# Altium schematic sheet IO.SchDoc
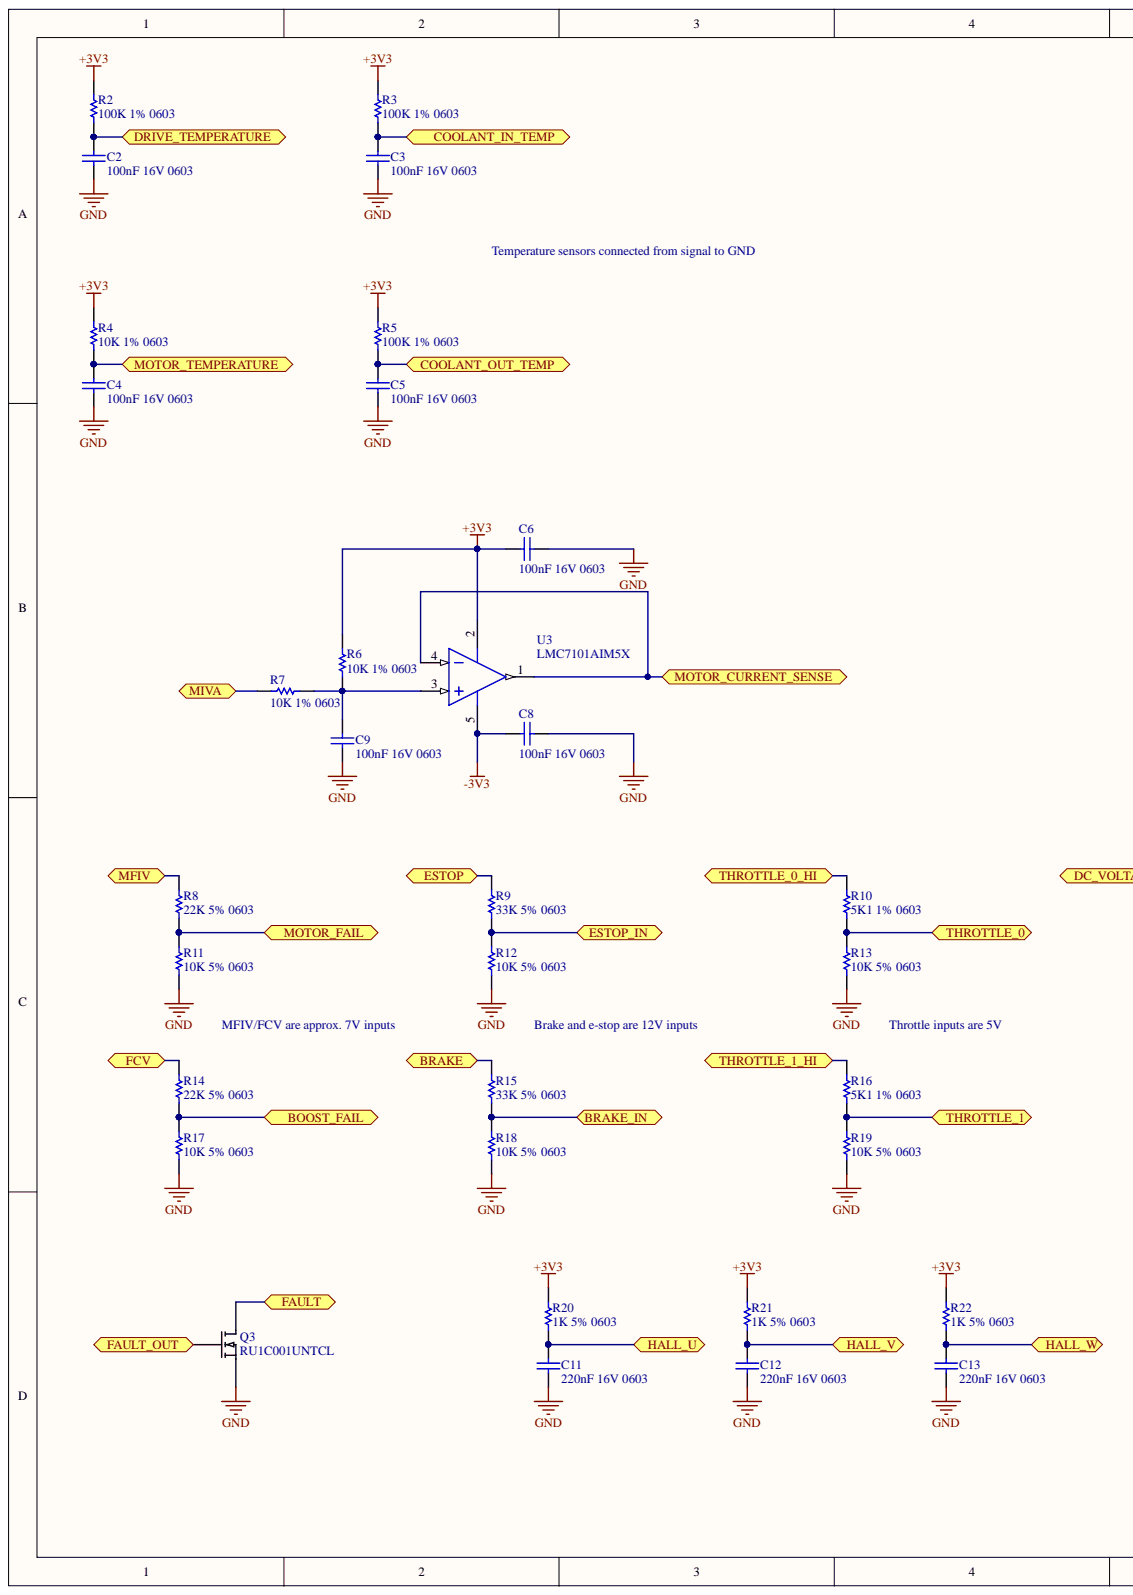
[diagram p0: IO.SchDoc, left]
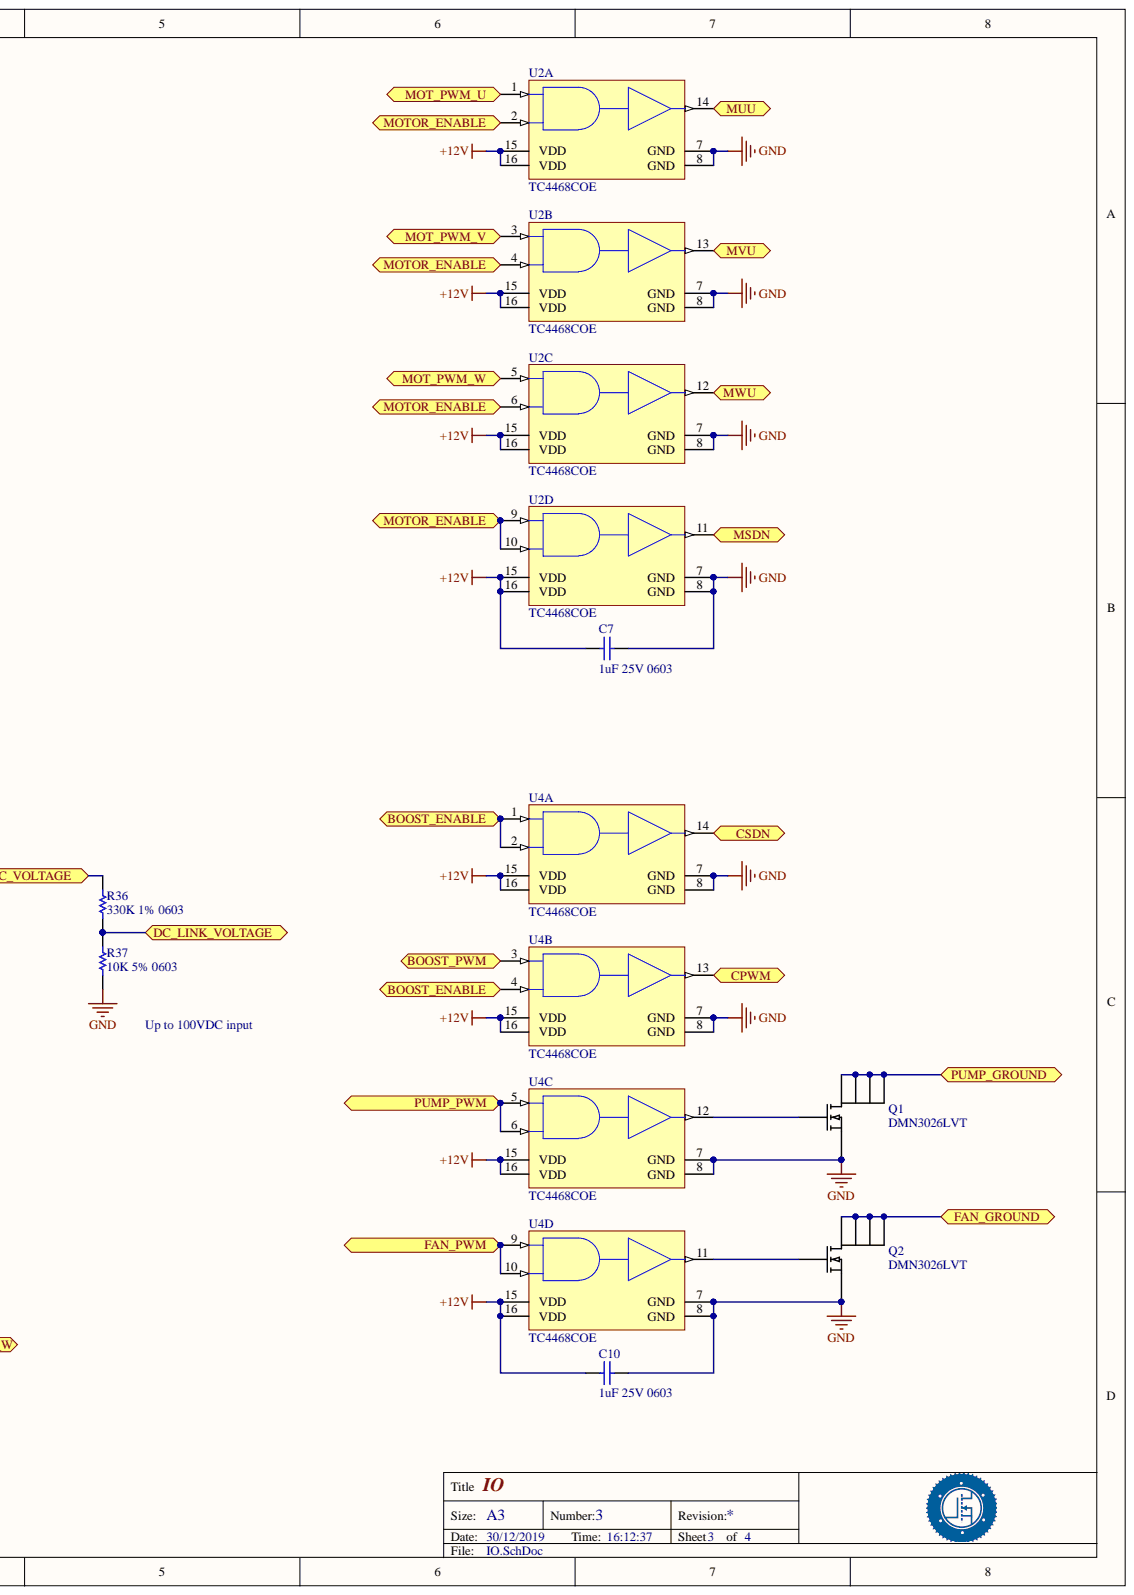
[diagram p1: IO.SchDoc, right]

## Parts
C2  100nF 16V 0603  value 100nF  [CAPC0603(1608)100_N]
C3  100nF 16V 0603  value 100nF  [CAPC0603(1608)100_N]
C4  100nF 16V 0603  value 100nF  [CAPC0603(1608)100_N]
C5  100nF 16V 0603  value 100nF  [CAPC0603(1608)100_N]
C6  100nF 16V 0603  value 100nF  Case-EIA: 0603  [CAPC0603(1608)100_N]
C7  1uF 25V 0603  value 1uF  Case-EIA: 0603  [CAPC0603(1608)100_N]
C8  100nF 16V 0603  value 100nF  Case-EIA: 0603  [CAPC0603(1608)100_N]
C9  100nF 16V 0603  value 100nF  Case-EIA: 0603  [CAPC0603(1608)100_N]
C10  1uF 25V 0603  value 1uF  Case-EIA: 0603  [CAPC0603(1608)100_N]
C11  220nF 16V 0603  value 220nF  Case-EIA: 0603  [CAPC0603(1608)100_N]
C12  220nF 16V 0603  value 220nF  Case-EIA: 0603  [CAPC0603(1608)100_N]
C13  220nF 16V 0603  value 220nF  Case-EIA: 0603  [CAPC0603(1608)100_N]
Q1  DMN3026LVT  [SOT23-6]
Q2  DMN3026LVT  [SOT23-6]
Q3  RU1C001UNTCL  [SOT23]
R2  100K 1% 0603  [RESC0603(1608)_N]
R3  100K 1% 0603  [RESC0603(1608)_N]
R4  10K 1% 0603  [RESC0603(1608)_N]
R5  100K 1% 0603  [RESC0603(1608)_N]
R6  10K 1% 0603  Case-EIA: 0603  [RESC0603(1608)_N]
R7  10K 1% 0603  Case-EIA: 0603  [RESC0603(1608)_N]
R8  22K 5% 0603  [RESC0603(1608)_N]
R9  33K 5% 0603  [RESC0603(1608)_N]
R10  5K1 1% 0603  [RESC0603(1608)_N]
R11  10K 5% 0603  [RESC0603(1608)_N]
R12  10K 5% 0603  [RESC0603(1608)_N]
R13  10K 5% 0603  [RESC0603(1608)_N]
R14  22K 5% 0603  [RESC0603(1608)_N]
R15  33K 5% 0603  [RESC0603(1608)_N]
R16  5K1 1% 0603  [RESC0603(1608)_N]
R17  10K 5% 0603  [RESC0603(1608)_N]
R18  10K 5% 0603  [RESC0603(1608)_N]
R19  10K 5% 0603  [RESC0603(1608)_N]
R20  1K 5% 0603  [RESC0603(1608)_N]
R21  1K 5% 0603  [RESC0603(1608)_N]
R22  1K 5% 0603  [RESC0603(1608)_N]
R36  330K 1% 0603  [RESC0603(1608)_N]
R37  10K 5% 0603  [RESC0603(1608)_N]
U2  TC4468COE  [SOIC-OE16_M]  (parts A,B,C,D)
U3  LMC7101AIM5X  [DBV0005A_L]
U4  TC4468COE  [SOIC-OE16_M]  (parts A,B,C,D)

## Ports
BOOST_ENABLE, BOOST_FAIL, BOOST_PWM, BRAKE, BRAKE_IN, COOLANT_IN_TEMP, COOLANT_OUT_TEMP, CPWM, CSDN, DC_LINK_VOLTAGE, DC_VOLTAGE, DRIVE_TEMPERATURE, ESTOP, ESTOP_IN, FAN_GROUND, FAN_PWM, FAULT, FAULT_OUT, FCV, HALL_U, HALL_V, HALL_W, MFIV, MIVA, MOT_PWM_U, MOT_PWM_V, MOT_PWM_W, MOTOR_CURRENT_SENSE, MOTOR_ENABLE, MOTOR_FAIL, MOTOR_TEMPERATURE, MSDN, MUU, MVU, MWU, PUMP_GROUND, PUMP_PWM, THROTTLE_0, THROTTLE_0_HI, THROTTLE_1, THROTTLE_1_HI

## Nets
+3V3: C6.2 R2.2 R3.2 R4.2 R5.2 R6.1 R20.2 R21.2 R22.2 U3.2
+12V: C7.1 C10.1 U2.15(VDD) U2.16(VDD) U4.15(VDD) U4.16(VDD)
-3V3: C8.2 U3.5
GND: C2.2 C3.2 C4.1 C5.2 C6.1 C7.2 C8.1 C9.2 C10.2 C11.1 C12.1 C13.1 Q1.4 Q2.4 Q3.2 R11.2 R12.2 R13.2 R17.2 R18.2 R19.2 R37.2 U2.7(GND) U2.8(GND) U4.7(GND) U4.8(GND)
BOOST_ENABLE: U4.1 U4.2 U4.4 ; port BOOST_ENABLE
BOOST_FAIL: R14.2 R17.1 ; port BOOST_FAIL
BOOST_PWM: U4.3 ; port BOOST_PWM
BRAKE: R15.2 ; port BRAKE
BRAKE_IN: R15.1 R18.1 ; port BRAKE_IN
COOLANT_IN_TEMP: C3.1 R3.1 ; port COOLANT_IN_TEMP
COOLANT_OUT_TEMP: C5.1 R5.1 ; port COOLANT_OUT_TEMP
CPWM: U4.13 ; port CPWM
CSDN: U4.14 ; port CSDN
DC_LINK_VOLTAGE: R36.1 R37.1 ; port DC_LINK_VOLTAGE
DC_VOLTAGE: R36.2 ; port DC_VOLTAGE
DRIVE_TEMPERATURE: C2.1 R2.1 ; port DRIVE_TEMPERATURE
ESTOP: R9.2 ; port ESTOP
ESTOP_IN: R9.1 R12.1 ; port ESTOP_IN
FAN_GROUND: Q2.1 Q2.2 Q2.5 Q2.6 ; port FAN_GROUND
FAN_PWM: U4.9 U4.10 ; port FAN_PWM
FAULT: Q3.3 ; port FAULT
FAULT_OUT: Q3.1 ; port FAULT_OUT
FCV: R14.1 ; port FCV
HALL_U: C11.2 R20.1 ; port HALL_U
HALL_V: C12.2 R21.1 ; port HALL_V
HALL_W: C13.2 R22.1 ; port HALL_W
MFIV: R8.1 ; port MFIV
MIVA: R7.1 ; port MIVA
MOT_PWM_U: U2.1 ; port MOT_PWM_U
MOT_PWM_V: U2.3 ; port MOT_PWM_V
MOT_PWM_W: U2.5 ; port MOT_PWM_W
MOTOR_CURRENT_SENSE: U3.1 U3.4 ; port MOTOR_CURRENT_SENSE
MOTOR_ENABLE: U2.2 U2.4 U2.6 U2.9 U2.10 ; port MOTOR_ENABLE
MOTOR_FAIL: R8.2 R11.1 ; port MOTOR_FAIL
MOTOR_TEMPERATURE: C4.2 R4.1 ; port MOTOR_TEMPERATURE
MSDN: U2.11 ; port MSDN
MUU: U2.14 ; port MUU
MVU: U2.13 ; port MVU
MWU: U2.12 ; port MWU
PUMP_GROUND: Q1.1 Q1.2 Q1.5 Q1.6 ; port PUMP_GROUND
PUMP_PWM: U4.5 U4.6 ; port PUMP_PWM
THROTTLE_0: R10.1 R13.1 ; port THROTTLE_0
THROTTLE_0_HI: R10.2 ; port THROTTLE_0_HI
THROTTLE_1: R16.1 R19.1 ; port THROTTLE_1
THROTTLE_1_HI: R16.2 ; port THROTTLE_1_HI
NetC9_1: C9.1 R6.2 R7.2 U3.3
NetQ1_3: Q1.3 U4.12
NetQ2_3: Q2.3 U4.11

## Library data of the parts
C2-C5 = CMP-1035-02585-1: CAP 100nF 16V ±20% 0603 (1608 Metric) Thickness 1mm SMD; Case-EIA: 0603; Case-Metric: 1608; Tolerance: Â±20%; Component Kind: Standard; Library Name: Altium Content Vault; Max Thickness: 1 mm; Rated Voltage: 16 V; Technology: SMT
C6 C8 C9 = CMP-1035-02585-1: CAP 100nF 16V ±20% 0603 (1608 Metric) Thickness 1mm SMD; Case-Metric: 1608; Tolerance: Â±20%; Component Kind: Standard; Library Name: Altium Content Vault; Max Thickness: 1 mm; Rated Voltage: 16 V; Technology: SMT
C7 C10 = CMP-1035-02740-1: CAP 1uF 25V ±10% 0603 (1608 Metric) Thickness 1mm SMD; Case-Metric: 1608; Tolerance: Â±10%; Component Kind: Standard; Library Name: Altium Content Vault; Max Thickness: 1 mm; Rated Voltage: 25 V; Technology: SMT
C11-C13 = CMP-1035-02644-1: CAP 220nF 16V ±10% 0603 (1608 Metric) Thickness 1mm SMD; Case-Metric: 1608; Tolerance: Â±10%; Component Kind: Standard; Library Name: Altium Content Vault; Max Thickness: 1 mm; Rated Voltage: 16 V; Technology: SMT
Q1 Q2 = DMN2306LVT: 30V 6.6A N-CHANNEL ENHANCEMENT MODE MOSFET; Manufacturer: Diodes Incorporated; Component Kind: Standard; Library Name: sl-altium.SchLib; PartNumber: DMN3026LVT
Q3 = RU1C001UNTCL: N-channel 20V 100mA Small Signal MOSFET; Manufacturer: Rohm; Component Kind: Standard; Library Name: sl-altium.SchLib; PartNumber: RU1C001UNTCL
R2 R3 R5 = CMP-1012-00623-1: 100K 0.1W 1% 0603 (1608 Metric)  SMD; Case-EIA: 0603; Case-Metric: 1608; Value: 100000.00 Ohm; Component Kind: Standard; Library Name: Altium Content Vault; Power: 0.1 W; Technology: SMT; Tolerance: 1 %
R4 = CMP-1012-00623-1: 10K 0.1W 1% 0603 (1608 Metric)  SMD; Case-EIA: 0603; Case-Metric: 1608; Value: 10000.00 Ohm; Component Kind: Standard; Library Name: Altium Content Vault; Power: 0.1 W; Technology: SMT; Tolerance: 1 %
R6 R7 = CMP-1012-00623-1: 10K 0.1W 1% 0603 (1608 Metric)  SMD; Case-Metric: 1608; Value: 10000.00 Ohm; Component Kind: Standard; Library Name: Altium Content Vault; Power: 0.1 W; Technology: SMT; Tolerance: 1 %
R8 R14 = CMP-1012-00106-1: 22K 0.1W 5% 0603 (1608 Metric)  SMD; Case-EIA: 0603; Case-Metric: 1608; Value: 22000.00 Ohm; Component Kind: Standard; Library Name: Altium Content Vault; Power: 0.1 W; Technology: SMT; Tolerance: 5 %
R9 R15 = CMP-1012-00110-1: 33K 0.1W 5% 0603 (1608 Metric)  SMD; Case-EIA: 0603; Case-Metric: 1608; Value: 33000.00 Ohm; Component Kind: Standard; Library Name: Altium Content Vault; Power: 0.1 W; Technology: SMT; Tolerance: 5 %
R10 R16 = CMP-1012-00590-1: 5K1 0.1W 1% 0603 (1608 Metric)  SMD; Case-EIA: 0603; Case-Metric: 1608; Value: 5100.00 Ohm; Component Kind: Standard; Library Name: Altium Content Vault; Power: 0.1 W; Technology: SMT; Tolerance: 1 %
R11-R13 R17-R19 R37 = CMP-1012-00098-1: 10K 0.1W 5% 0603 (1608 Metric)  SMD; Case-EIA: 0603; Case-Metric: 1608; Value: 10000.00 Ohm; Component Kind: Standard; Library Name: Altium Content Vault; Power: 0.1 W; Technology: SMT; Tolerance: 5 %
R20-R22 = CMP-1012-00074-1: 1K 0.1W 5% 0603 (1608 Metric)  SMD; Case-EIA: 0603; Case-Metric: 1608; Value: 1000.00 Ohm; Component Kind: Standard; Library Name: Altium Content Vault; Power: 0.1 W; Technology: SMT; Tolerance: 5 %
R36 = CMP-1012-00793-1: 330K 0.1W 1% 0603 (1608 Metric)  SMD; Case-EIA: 0603; Case-Metric: 1608; Value: 330000.00 Ohm; Component Kind: Standard; Library Name: Altium Content Vault; Power: 0.1 W; Technology: SMT; Tolerance: 1 %
U2 U4 = CMP-0137-00207-1: 1.2A Quad MOSFET Driver, AND, Commercial Temperature; Code_IPC: SOIC127P1030-16; Code_JEDEC: MS-013-AA; Component Kind: Standard; DatasheetVersion: revB, Feb-2003; Library Name: Altium Content Vault; PackageDescription: 16-Lead Plastic Small Outline (OE) - Wide 7.50mm Body [SOIC]; PackageReference: SOIC-OE16; PackageVersion: revBB, Aug-2009
U3 = CMP-0055-01331-3: Tiny Low Power Operational Amplifier with Rail-to-Rail Input and Output, 5-pin SOT-23; Manufacturer: Texas Instruments; CompType: Amplifiers; PackageTopMarking: A00A*XTT; PowerWise: N; Component Kind: Standard; ComponentLink1Description: Datasheet URL; ComponentLink1URL: http://www.ti.com/general/docs/lit/getliterature.tsp?genericPartNumber=LMC7101&fileType=pdf; Library Name: Altium Content Vault; PackageDescription: Molded SOT-23, .115 x .063 x .040 in Body, 5 Lead, .0375 in Pitch; Package Reference: DBV0005A; PinCount: 5; RoHS: N
